# Altium schematic sheet 18_HD4_PUMP.SchDoc(18_HD4_PUMP)
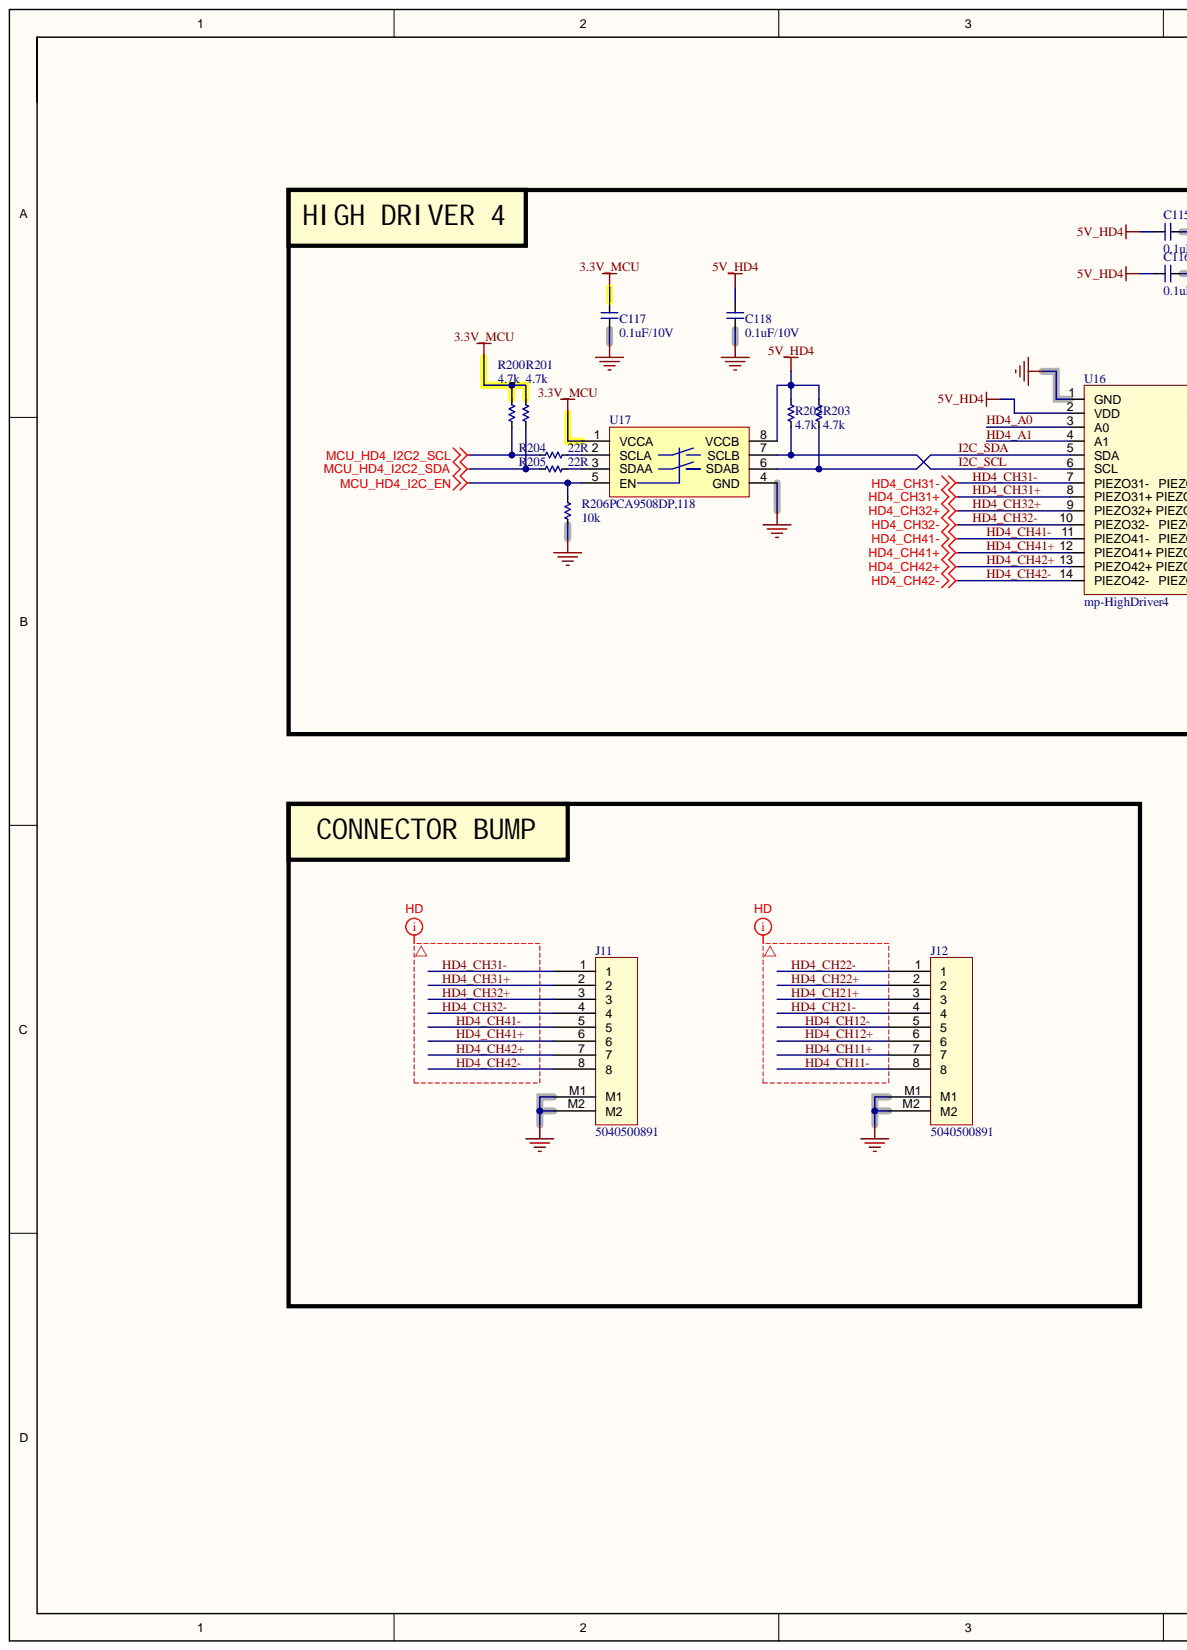
[diagram p0: 18_HD4_PUMP.SchDoc, left]
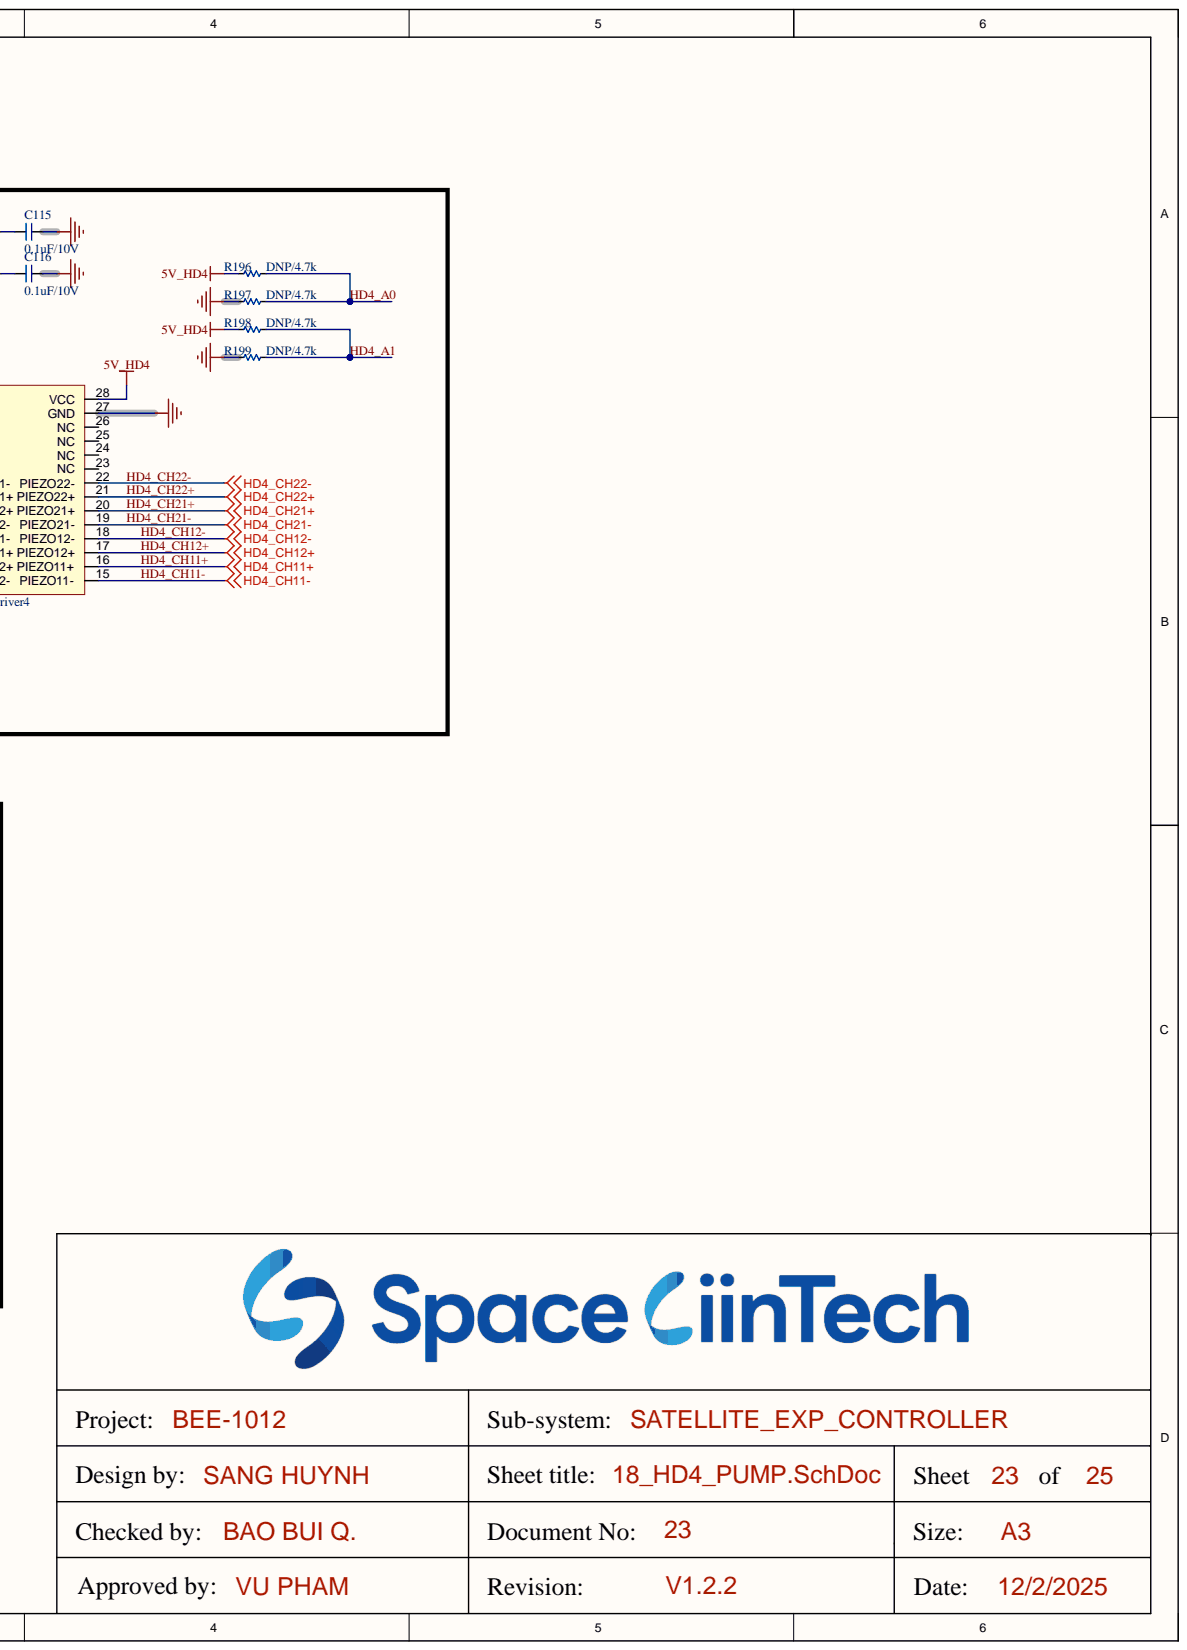
[diagram p1: 18_HD4_PUMP.SchDoc, right]

## Parts
C115  0.1uF/10V  [CAP_C_1005X60]
C116  0.1uF/10V  [CAP_C_1005X60]
C117  0.1uF/10V  [CAP_C_1005X60]
C118  0.1uF/10V  [CAP_C_1005X60]
J11  5040500891  [MOLEX_5040500891]
J12  5040500891  [MOLEX_5040500891]
R196  DNP/4.7k  [RES_C_1005X40]
R197  DNP/4.7k  [RES_C_1005X40]
R198  DNP/4.7k  [RES_C_1005X40]
R199  DNP/4.7k  [RES_C_1005X40]
R200  4.7k  [RES_C_1005X40]
R201  4.7k  [RES_C_1005X40]
R202  4.7k  [RES_C_1005X40]
R203  4.7k  [RES_C_1005X40]
R204  22R  [RES_C_1005X40]
R205  22R  [RES_C_1005X40]
R206  10k  [RES_C_1005X40]
U16  mp-HighDriver4  [MP_HIGHDRIVER4]
U17  PCA9508DP,118  [TSOP8]

## Nets
3.3V_MCU: C117.2 R200.1 R201.1 U17.1(VCCA)
5V_HD4: C115.2 C116.2 C118.2 R196.1 R198.1 R202.1 R203.1 U16.2(VDD) U16.28(VCC) U17.8(VCCB)
GND: C115.1 C116.1 C117.1 C118.1 J11.M1 J11.M2 J12.M1 J12.M2 R197.1 R199.1 R206.2 U16.1(GND) U16.27(GND) U17.4(GND)
MCU_HD4_I2C2_SCL: R200.2 R204.2
MCU_HD4_I2C2_SDA: R201.2 R205.2
MCU_HD4_I2C_EN: R206.1 U17.5(EN)
HD4_A0: R196.2 R197.2 U16.3(A0)
HD4_A1: R198.2 R199.2 U16.4(A1)
HD4_CH11+: J12.7 U16.16(PIEZO11+)
HD4_CH11-: J12.8 U16.15(PIEZO11-)
HD4_CH12+: J12.6 U16.17(PIEZO12+)
HD4_CH12-: J12.5 U16.18(PIEZO12-)
HD4_CH21+: J12.3 U16.20(PIEZO21+)
HD4_CH21-: J12.4 U16.19(PIEZO21-)
HD4_CH22+: J12.2 U16.21(PIEZO22+)
HD4_CH22-: J12.1 U16.22(PIEZO22-)
HD4_CH31+: J11.2 U16.8(PIEZO31+)
HD4_CH31-: J11.1 U16.7(PIEZO31-)
HD4_CH32+: J11.3 U16.9(PIEZO32+)
HD4_CH32-: J11.4 U16.10(PIEZO32-)
HD4_CH41+: J11.6 U16.12(PIEZO41+)
HD4_CH41-: J11.5 U16.11(PIEZO41-)
HD4_CH42+: J11.7 U16.13(PIEZO42+)
HD4_CH42-: J11.8 U16.14(PIEZO42-)
I2C_SCL: R202.2 U16.6(SCL) U17.7(SCLB)
I2C_SDA: R203.2 U16.5(SDA) U17.6(SDAB)
NetR204_1: R204.1 U17.2(SCLA)
NetR205_1: R205.1 U17.3(SDAA)

Not connected: U16 23(NC) 24(NC) 25(NC) 26(NC)

## Library data of the parts
C115-C118 = _CAP_C_2: CAP CHIP; Component Kind: Standard; Library Name: LABLIB.SchLib
J11 J12 = Header 8x1_2M: Component Kind: Standard; Library Name: LABLIB.SchLib
R196-R206 = _RES_B_2: RES; Component Kind: Standard; Library Name: LABLIB.SchLib
U16 = mp_HighDriver4: Component Kind: Standard; Library Name: new_component.SchLib
U17 = PCA9508DP,118: Component Kind: Standard; Library Name: EXP_HW_V3.SchLib
